# Altium schematic sheet SH_SFW3_V3.0.SchDot
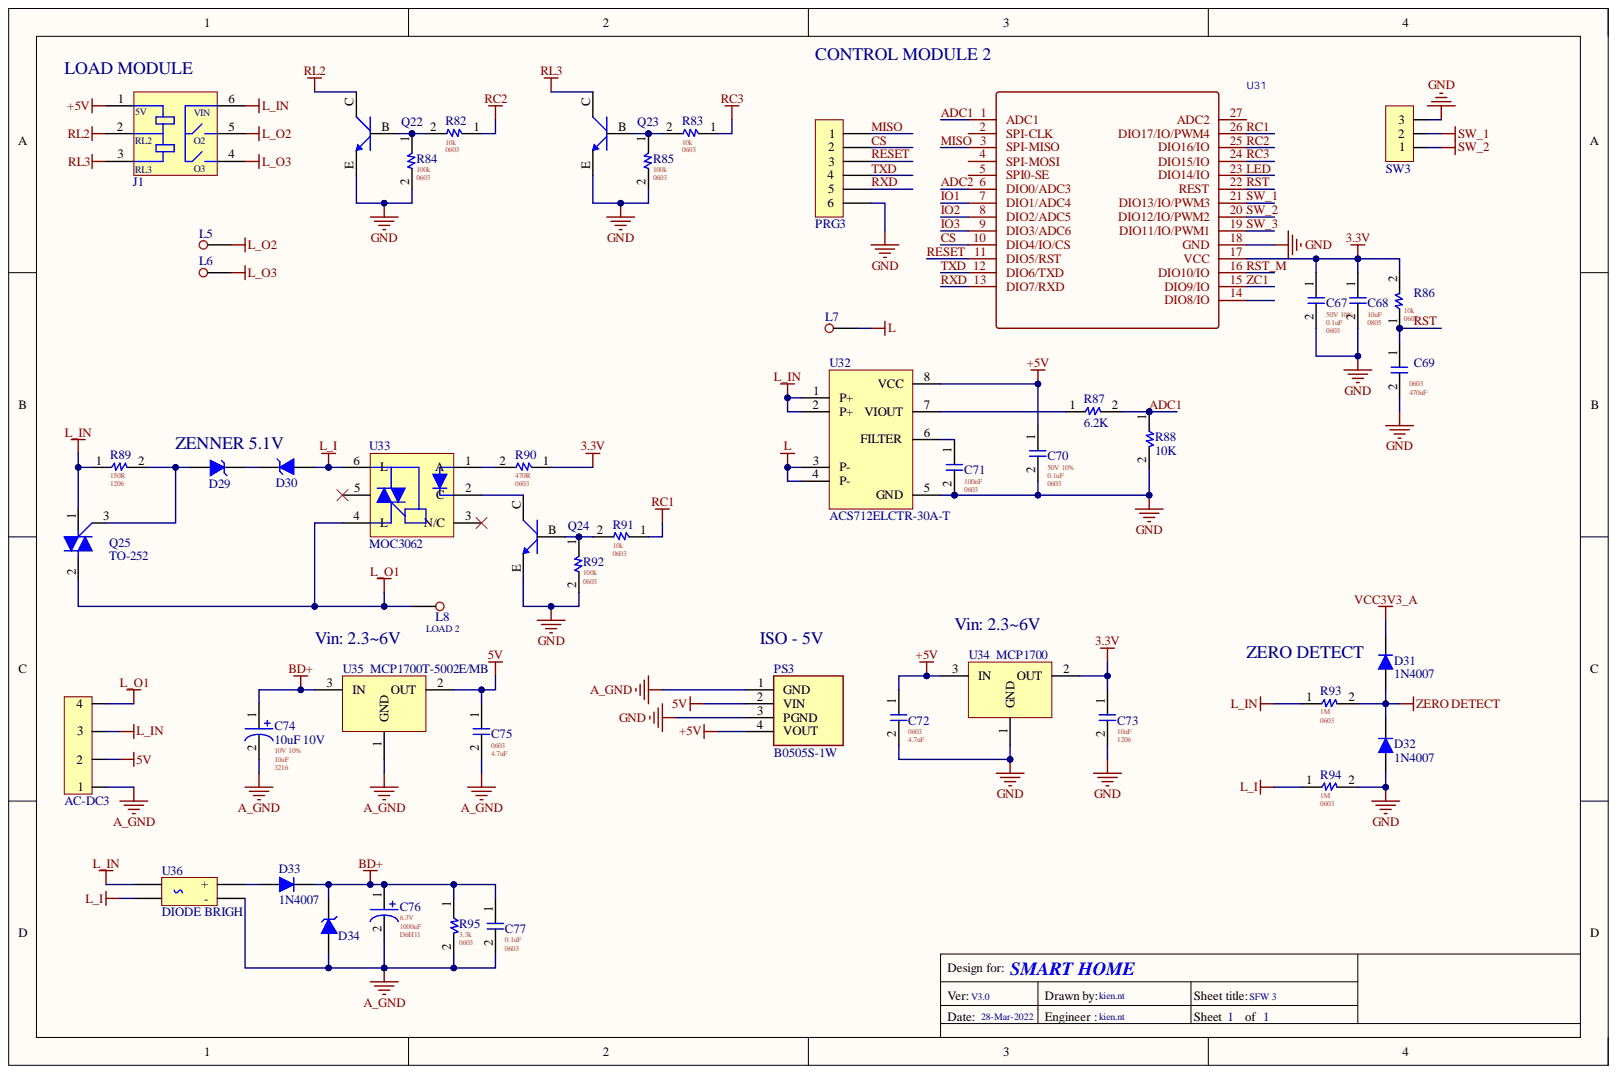
[diagram p0: SH_SFW3_V3.0.SchDot]

## Parts
AC-DC3  P4  [AC-DC 5V K4H24]  (value not drawn)
C67  0.1uF  FP: 0603  VOLTAGE + TOLE: 50V 10%  [0603 C]
C68  10uF  FP: 0805  [0805 C]
C69  470nF  FP: 0603  [0603 C]
C70  0.1uF  FP: 0603  VOLTAGE + TOLE: 50V 10%  [0603 C]
C71  value 100nF  FP: 0603  [0603 C]  (hidden comment: 1nF)
C72  4.7uF  FP: 0603  [0603 C]
C73  10uF  FP: 1206  [1206 C]
C74  10uF 10V  value 10uF  FP: 3216  [CAP-TAN 3216]
C75  4.7uF  FP: 0603  [0603 C]
C76  1000uF 6.3V  value 1000uF  FP: D6H11  VOLTAGE + TOLE: 6.3V  [CAP-AE 6.3x11 DIP2.54]
C77  0.1uF  FP: 0603  [0603 C]
D29  5.1V  [SOD-80C]
D30  5.1V  [SOD-80C]
D31  1N4007  [SOD-123]
D32  1N4007  [SOD-123]
D33  1N4007  [DO214AC]
D34  5.1V  [SOD-80C]  (value not drawn)
J1  RELAY MODULE  [RELAY 2, MODULE]  (value not drawn)
L5  P1  [HOLE AC]  (value not drawn)
L6  P1  [HOLE AC]  (value not drawn)
L7  P1  [HOLE AC]  (value not drawn)
L8  P1  [HOLE AC]  (value not drawn)
PRG3  P6  [P6 - 1.27MM]  (value not drawn)
PS3  B0505S-1W  [B0505S1W]
Q22  MMBT3904  [SOT 23-3 - BCE]  (value not drawn)
Q23  MMBT3904  [SOT 23-3 - BCE]  (value not drawn)
Q24  MMBT3904  [SOT 23-3 - BCE]  (value not drawn)
Q25  TO-252  [TO-252]
R82  10k  FP: 0603  [0603 R]
R83  10k  FP: 0603  [0603 R]
R84  100k  FP: 0603  [0603 R]
R85  100k  FP: 0603  [0603 R]
R86  10k  FP: 0603  [0603 R]
R87  6.2K  [0603 R]
R88  10K  [0603 R]
R89  150R  FP: 1206  [1206 R]
R90  470R  FP: 0603  [0603 R]
R91  10k  FP: 0603  [0603 R]
R92  100k  FP: 0603  [0603 R]
R93  1M  FP: 0603  [0603 R]
R94  1M  FP: 0603  [0603 R]
R95  3.3k  FP: 0603  [0603 R]
SW3  P3  [JST 3PIN - 2.54mm]  (value not drawn)
U31  (no value)  [NXP-E75-10S]
U32  ACS712ELCTR-30A-T  [SOIC 8]
U33  MOC3062  [6-PIN DIP]
U34  MCP1700  [MCP1700]
U35  MCP1700T-5002E/MB  [MCP1700]
U36  DIODE BRIGH  [4PIN SOP]

## Nets
3.3V: C67.1 C68.1 C73.1 R86.2 R90.1 U31.17(VCC) U34.2
5V: AC-DC3.2 C70.1 C72.1 C75.1 J1.1 PS3.2(VIN) PS3.4(VOUT) U32.8(VCC) U34.3 U35.2
A_GND: AC-DC3.1 C74.2 C75.2 C76.2 C77.2 D34.1 PS3.1(GND) R95.2 U35.1 U36.2(-)
BD+: C74.1 C76.1 C77.1 D33.2 D34.2 R95.1 U35.3
GND: C67.2 C68.2 C69.2 C70.2 C71.2 C72.2 C73.2 D32.1 PRG3.6 PS3.3(PGND) Q22.E Q23.E Q24.E R84.2 R85.2 R88.2 R92.2 R94.2 SW3.3 U31.18(GND) U32.5(GND) U34.1
L: L7.1 U32.3(P-) U32.4(P-)
L_I: D30.1 R94.1 U33.6(L) U36.4
L_IN: AC-DC3.3 J1.6 Q25.1 R89.1 R93.1 U32.1(P+) U32.2(P+) U36.3
L_O1: AC-DC3.4 L8.1 Q25.2 U33.4(L)
L_O2: J1.5 L5.1
L_O3: J1.4 L6.1
RL2: J1.2 Q22.C
RL3: J1.3 Q23.C
VCC3V3_A: D31.2
ZERO DETECT: D31.1 D32.2 R93.2
ADC1: R87.2 R88.1 U31.1(ADC1)
ADC2: U31.6(DIO0/ADC3)
CS: PRG3.2 U31.10(DIO4/IO/CS)
IO1: U31.7(DIO1/ADC4)
IO2: U31.8(DIO2/ADC5)
IO3: U31.9(DIO3/ADC6)
LED: U31.23(DIO14/IO)
MISO: PRG3.1 U31.3(SPI-MISO)
RC1: R91.1 U31.26(DIO17/IO/PWM4)
RC2: R82.1 U31.25(DIO16/IO)
RC3: R83.1 U31.24(DIO15/IO)
RESET: PRG3.3 U31.11(DIO5/RST)
RST: C69.1 R86.1 U31.22(REST)
RST_M: U31.16(DIO10/IO)
RXD: PRG3.5 U31.13(DIO7/RXD)
SW_1: SW3.2 U31.21(DIO13/IO/PWM3)
SW_2: SW3.1 U31.20(DIO12/IO/PWM2)
SW_3: U31.19(DIO11/IO/PWM1)
TXD: PRG3.4 U31.12(DIO6/TXD)
ZC1: U31.15(DIO9/IO)
NetC71_1: C71.1 U32.6(FILTER)
NetD29_1: D29.1 Q25.3 R89.2
NetD29_2: D29.2 D30.2
NetD33_1: D33.1 U36.1(+)
NetQ22_B: Q22.B R82.2 R84.1
NetQ23_B: Q23.B R83.2 R85.1
NetQ24_B: Q24.B R91.2 R92.1
NetQ24_C: Q24.C U33.2(C)
NetR87_1: R87.1 U32.7(VIOUT)
NetR90_2: R90.2 U33.1(A)

Not connected: U31 2(SPI-CLK) 4(SPI-MOSI) 5(SPI0-SE) 14(DIO8/IO) 27(ADC2); U33 3(N/C) 5

## Library data of the parts
C67 C70 = 0603 0.1uF CER: CAP CER 0.1uF 50V 10% 0603 SMD; Component Kind: Standard; ComponentLink1Description: New link; DATE: 04-Mar-21; Library Name: Capacitor.SchLib; PACKAGE: SMD; VALUE: 0.1uF; CREATOR: MMUOP
C68 = 0805 10uF CER: CAP CER 10uF 50V 10% 0805 SMD; VOLTAGE + TOLE: 50V 10%; Component Kind: Standard; ComponentLink1Description: New link; DATE: 04-Mar-21; Library Name: Capacitor.SchLib; PACKAGE: SMD; VALUE: 10uF; CREATOR: MMUOP
C69 = 0603 470nF CER: CAP CER 470nF 50V 10% 0603 SMD; VOLTAGE + TOLE: 50V 10%; Component Kind: Standard; ComponentLink1Description: New link; DATE: 04-Mar-21; Library Name: Capacitor.SchLib; PACKAGE: SMD; VALUE: 470nF; CREATOR: MMUOP
C71 = 0603 1nF CER: CAP CER 1nF 50V 10% 0603 SMD; VOLTAGE + TOLE: 50V 10%; Component Kind: Standard; ComponentLink1Description: New link; DATE: 04-Mar-21; Library Name: Capacitor.SchLib; PACKAGE: SMD; CREATOR: MMUOP
C72 C75 = 0603 4.7uF CER: CAP CER 4.7uF 50V 10% 0603 SMD; VOLTAGE + TOLE: 50V 10%; Component Kind: Standard; ComponentLink1Description: New link; DATE: 04-Mar-21; Library Name: Capacitor.SchLib; PACKAGE: SMD; VALUE: 4.7uF; CREATOR: MMUOP
C73 = 1206 10uF CER: CAP CER 10uF 50V 10% 0805 SMD; VOLTAGE + TOLE: 50V 10%; Component Kind: Standard; ComponentLink1Description: New link; DATE: 04-Mar-21; Library Name: Capacitor.SchLib; PACKAGE: SMD; VALUE: 10uF; CREATOR: MMUOP
C74 = CAP-TAN 3216 SMD_2: CAP-TAN 10uF 10V 10% 3216 SMD; VOLTAGE + TOLE: 10V 10%; Component Kind: Standard; ComponentLink1Description: New link; ComponentLink1URL: https://www.thegioiic.com/products/tu-tantalum-10uf-10v-1206-taja106k010rnj; DATE: 04-Mar-21; Library Name: Capacitor.SchLib; PACKAGE: SMD; CREATOR: MMUOP
C76 = CAP-AE 6.3X11mm TH: CAP AE 1000uF 6.3V 20%  D6.3 H11 TH; Component Kind: Standard; ComponentLink1Description: New link; DATE: 04-Mar-21; Library Name: Capacitor.SchLib; PACKAGE: TH; CREATOR: MMUOP
C77 = 0603 0.1uF CER: CAP CER 0.1uF 50V 10% 0603 SMD; VOLTAGE + TOLE: 50V 10%; Component Kind: Standard; ComponentLink1Description: New link; DATE: 04-Mar-21; Library Name: Capacitor.SchLib; PACKAGE: SMD; VALUE: 0.1uF; CREATOR: MMUOP
D29 D30 D34 = Diode Zener: DIODE ZENER 5.1V 1W; Editor: MMUOP; Component Kind: Standard; Library Name: Kienlib.SchLib
D31-D33 = Diode schottky: Component Kind: Standard; Library Name: Kienlib.SchLib
J1 = RELAY2 MODULE: Component Kind: Standard; Library Name: Kienlib.SchLib
PS3 = B0505S-1W: Power Supply; Manufacturer_Name: Mornsun; Component Kind: Standard; Height: 10.41mm; Library Name: SamacSys.SchLib; Manufacturer_Part_Number: B0505S-1W
Q22-Q24 = TRANS NPN_BCE: Component Kind: Standard; Library Name: Kienlib.SchLib
Q25 = TRIAC: Component Kind: Standard; Library Name: Kienlib.SchLib
R82 R83 R86 R91 = 0603 10k 1%: 10k 1% 0603 SMD; PN: C98220; Component Kind: Standard; ComponentLink1Description: New link; DATE: 04-Mar-21; Library Name: Res.SchLib; PACKAGE: SMD; VALUE: 10k; VOLTAGE + TOLE: 1%; CREATOR: MMUOP
R84 R85 R92 = 0603 100k 1%: 100k 1% 0603 SMD; Component Kind: Standard; ComponentLink1Description: New link; DATE: 04-Mar-21; Library Name: Res.SchLib; PACKAGE: SMD; VALUE: 100k; VOLTAGE + TOLE: 1%; CREATOR: MMUOP
R87 R88 = Res SMD: RES SMD 1K OHM 1% 0.15W 0603; Component Kind: Standard; Library Name: Kienlib.SchLib
R89 = 1206 150R 1%: 150R 1% 1206 SMD; Component Kind: Standard; ComponentLink1Description: New link; DATE: 04-Mar-21; Library Name: Res.SchLib; PACKAGE: SMD; VALUE: 150R; VOLTAGE + TOLE: 1%; CREATOR: MMUOP
R90 = 0603 470R 1%: 470R 1% 0603 SMD; Component Kind: Standard; ComponentLink1Description: New link; DATE: 04-Mar-21; Library Name: Res.SchLib; PACKAGE: SMD; VALUE: 470R; VOLTAGE + TOLE: 1%; CREATOR: MMUOP
R93 R94 = 0603 1M 1%: 1M 1% 0603 SMD; Component Kind: Standard; ComponentLink1Description: New link; DATE: 04-Mar-21; Library Name: Res.SchLib; PACKAGE: SMD; VALUE: 1M; VOLTAGE + TOLE: 1%; CREATOR: MMUOP
R95 = 0603 3.3k 1%: 3.3k 1% 0603 SMD; Component Kind: Standard; ComponentLink1Description: New link; DATE: 04-Mar-21; Library Name: Res.SchLib; PACKAGE: SMD; VALUE: 3.3k; VOLTAGE + TOLE: 1%; CREATOR: MMUOP
U31 = E75-2G4M10S: Component Kind: Standard
U32 = ACS71240: ACS712ELCTR-20A  SOIC 8; Component Kind: Standard; ComponentLink1Description: New link; ComponentLink1URL: https://banlinhkiendientu.vn/acs712t/; Library Name: Kienlib.SchLib
U33 = TRIAC & SCR: Component Kind: Standard; Library Name: Kienlib.SchLib
U35 = MCP1700: Component Kind: Standard; Library Name: Kienlib.SchLib
AC-DC3 = P4: Component Kind: Standard; Library Name: Kienlib.SchLib
L5-L8 = P1: Component Kind: Standard; Library Name: Kienlib.SchLib
PRG3 = P6: Component Kind: Standard; Library Name: Kienlib.SchLib
SW3 = P3: Component Kind: Standard; Library Name: Kienlib.SchLib
U34 = MCP1700: Component Kind: Standard; Library Name: Kienlib.SchLib
U36 = DIODE BRIGH: Component Kind: Standard; Library Name: Kienlib.SchLib
